# Altium schematic sheet 51MCUBoard.SchDoc
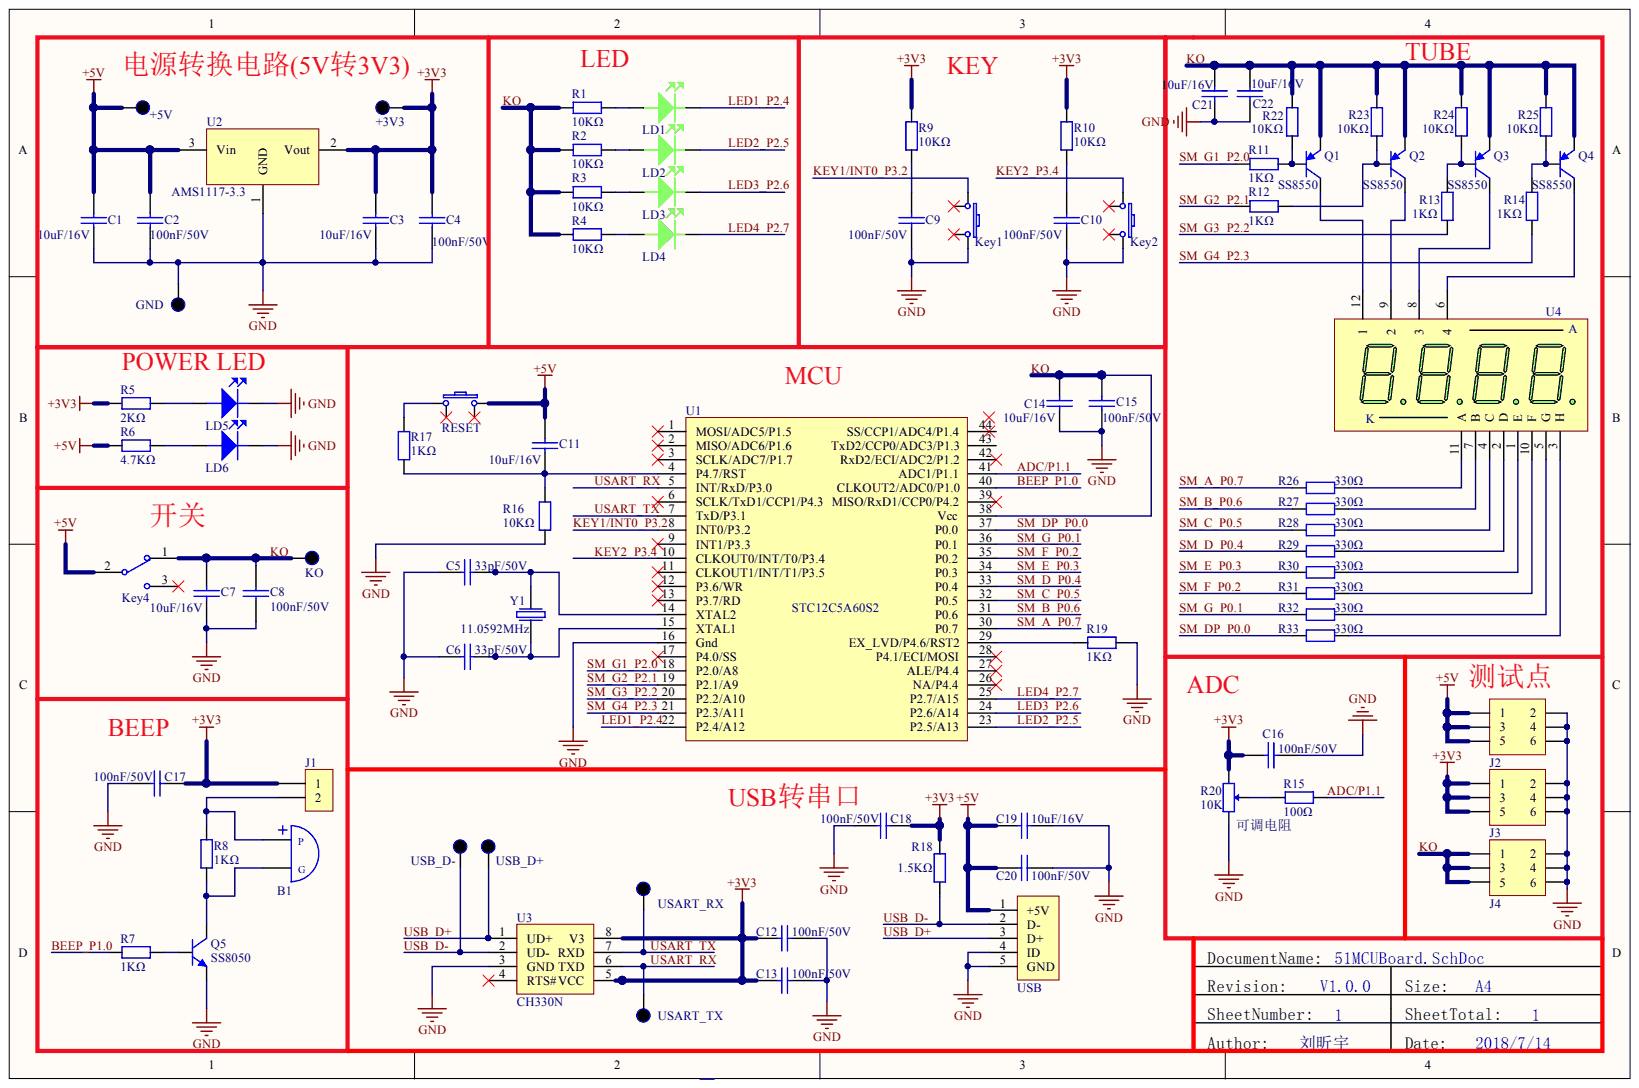
[diagram p0: 51MCUBoard.SchDoc]

## Parts
+3V3  TESTPOINT_0.9  [TESTPOINT_0.9]  (value not drawn)
+5V  TESTPOINT_0.9  [TESTPOINT_0.9]  (value not drawn)
B1  电磁蜂鸣器 有源9*5.5 3V  [BEEP 5x9x5.5]  (value not drawn)
C1  value 10uF/16V  [C 0603]  (hidden comment: 10uF (106) ±10% 16V SMD0603)
C2  value 100nF/50V  [C 0603]  (hidden comment: 100nF (104) ±10% 50V SMD0603)
C3  value 10uF/16V  [C 0603]  (hidden comment: 10uF (106) ±10% 16V SMD0603)
C4  value 100nF/50V  [C 0603]  (hidden comment: 100nF (104) ±10% 50V SMD0603)
C5  value 33pF/50V  [C 0603]  (hidden comment: 33pF (330) ±5% 50V SMD0603)
C6  value 33pF/50V  [C 0603]  (hidden comment: 33pF (330) ±5% 50V SMD0603)
C7  value 10uF/16V  [C 0603]  (hidden comment: 10uF (106) ±10% 16V SMD0603)
C8  value 100nF/50V  [C 0603]  (hidden comment: 100nF (104) ±10% 50V SMD0603)
C9  value 100nF/50V  [C 0603]  (hidden comment: 100nF (104) ±10% 50V SMD0603)
C10  value 100nF/50V  [C 0603]  (hidden comment: 100nF (104) ±10% 50V SMD0603)
C11  value 10uF/16V  [C 0603]  (hidden comment: 10uF (106) ±10% 16V SMD0603)
C12  value 100nF/50V  [C 0603]  (hidden comment: 100nF (104) ±10% 50V SMD0603)
C13  value 100nF/50V  [C 0603]  (hidden comment: 100nF (104) ±10% 50V SMD0603)
C14  value 10uF/16V  [C 0603]  (hidden comment: 10uF (106) ±10% 16V SMD0603)
C15  value 100nF/50V  [C 0603]  (hidden comment: 100nF (104) ±10% 50V SMD0603)
C16  value 100nF/50V  [C 0603]  (hidden comment: 100nF (104) ±10% 50V SMD0603)
C17  value 100nF/50V  [C 0603]  (hidden comment: 100nF (104) ±10% 50V SMD0603)
C18  value 100nF/50V  [C 0603]  (hidden comment: 100nF (104) ±10% 50V SMD0603)
C19  value 10uF/16V  [C 0603]  (hidden comment: 10uF (106) ±10% 16V SMD0603)
C20  value 100nF/50V  [C 0603]  (hidden comment: 100nF (104) ±10% 50V SMD0603)
C21  value 10uF/16V  [C 0603]  (hidden comment: 10uF (106) ±10% 16V SMD0603)
C22  value 10uF/16V  [C 0603]  (hidden comment: 10uF (106) ±10% 16V SMD0603)
GND  TESTPOINT_0.9  [TESTPOINT_0.9]  (value not drawn)
J1  排针 单排 2.54mm 2P  [HDR-1x2]  (value not drawn)
J2  排针 双排 2.54mm 2*3P  [HDR-2X3]  (value not drawn)
J3  排针 双排 2.54mm 2*3P  [HDR-2X3]  (value not drawn)
J4  排针 双排 2.54mm 2*3P  [HDR-2X3]  (value not drawn)
Key1  贴片轻触开关 6*6*6mm  [TSW SMD-6*6*6]  (value not drawn)
Key2  贴片轻触开关 6*6*6mm  [TSW SMD-6*6*6]  (value not drawn)
Key4  拨动开关 2档3脚 直角 美国C&K  [SS-12D06-Z]  (value not drawn)
KO  TESTPOINT_0.9  [TESTPOINT_0.9]  (value not drawn)
LD1  翠绿发光二极管  SMD0805  [LED 0805G]  (value not drawn)
LD2  翠绿发光二极管  SMD0805  [LED 0805G]  (value not drawn)
LD3  翠绿发光二极管  SMD0805  [LED 0805G]  (value not drawn)
LD4  翠绿发光二极管  SMD0805  [LED 0805G]  (value not drawn)
LD5  蓝色发光二极管  SMD0805  [LED 0805B]  (value not drawn)
LD6  蓝色发光二极管  SMD0805  [LED 0805B]  (value not drawn)
Q1  SS8550  [SOT23-3L]
Q2  SS8550  [SOT23-3L]
Q3  SS8550  [SOT23-3L]
Q4  SS8550  [SOT23-3L]
Q5  SS8050  [SOT23-3L]
R1  value 10KΩ  [R 0603]  (hidden comment: 10KΩ (1002) ±1% SMD0402)
R2  value 10KΩ  [R 0603]  (hidden comment: 10KΩ (1002) ±1% SMD0402)
R3  value 10KΩ  [R 0603]  (hidden comment: 10KΩ (1002) ±1% SMD0402)
R4  value 10KΩ  [R 0603]  (hidden comment: 10KΩ (1002) ±1% SMD0402)
R5  value 2KΩ  [R 0603]  (hidden comment: 2KΩ (2001) ±1%  SMD0603)
R6  value 4.7KΩ  [R 0603]  (hidden comment: 4.7KΩ (4701) ±1% SMD0603)
R7  value 1KΩ  [R 0603]  (hidden comment: 1KΩ (1001) ±1% SMD0603)
R8  value 1KΩ  [R 0603]  (hidden comment: 1KΩ (1001) ±1% SMD0603)
R9  value 10KΩ  [R 0603]  (hidden comment: 10KΩ (1002) ±1% SMD0402)
R10  value 10KΩ  [R 0603]  (hidden comment: 10KΩ (1002) ±1% SMD0402)
R11  value 1KΩ  [R 0603]  (hidden comment: 1KΩ (1001) ±1% SMD0603)
R12  value 1KΩ  [R 0603]  (hidden comment: 1KΩ (1001) ±1% SMD0603)
R13  value 1KΩ  [R 0603]  (hidden comment: 1KΩ (1001) ±1% SMD0603)
R14  value 1KΩ  [R 0603]  (hidden comment: 1KΩ (1001) ±1% SMD0603)
R15  value 100Ω  [R 0603]  (hidden comment: 100Ω (1000) ±1% SMD0603)
R16  value 10KΩ  [R 0603]  (hidden comment: 10KΩ (1002) ±1% SMD0402)
R17  value 1KΩ  [R 0603]  (hidden comment: 1KΩ (1001) ±1% SMD0603)
R18  value 1.5KΩ  [R 0603]  (hidden comment: 1.5KΩ (1501) ±1%  SMD0603)
R19  value 1KΩ  [R 0603]  (hidden comment: 1KΩ (1001) ±1% SMD0603)
R20  可调电阻  value 10K  [滑动变阻器]
R22  value 10KΩ  [R 0603]  (hidden comment: 10KΩ (1002) ±1% SMD0603)
R23  value 10KΩ  [R 0603]  (hidden comment: 10KΩ (1002) ±1% SMD0603)
R24  value 10KΩ  [R 0603]  (hidden comment: 10KΩ (1002) ±1% SMD0603)
R25  value 10KΩ  [R 0603]  (hidden comment: 10KΩ (1002) ±1% SMD0603)
R26  value 330Ω  [R 0603]  (hidden comment: 330Ω (3300) ±1% SMD0603)
R27  value 330Ω  [R 0603]  (hidden comment: 330Ω (3300) ±1% SMD0603)
R28  value 330Ω  [R 0603]  (hidden comment: 330Ω (3300) ±1% SMD0603)
R29  value 330Ω  [R 0603]  (hidden comment: 330Ω (3300) ±1% SMD0603)
R30  value 330Ω  [R 0603]  (hidden comment: 330Ω (3300) ±1% SMD0603)
R31  value 330Ω  [R 0603]  (hidden comment: 330Ω (3300) ±1% SMD0603)
R32  value 330Ω  [R 0603]  (hidden comment: 330Ω (3300) ±1% SMD0603)
R33  value 330Ω  [R 0603]  (hidden comment: 330Ω (3300) ±1% SMD0603)
RESET  贴片轻触开关 6*6*6mm  [TSW SMD-6*6*6]  (value not drawn)
U1  STC12C5A60S2  [LQFP44 10X10_N]
U2  AMS1117-3.3  [SOT223_N]
U3  CH330N  [LC-SOP-8_150mil]
U4  四位共阳黄绿色数码管  [SMG 0.36-4P]  (value not drawn)
USART_RX  TESTPOINT_0.9  [TESTPOINT_0.9]  (value not drawn)
USART_TX  TESTPOINT_0.9  [TESTPOINT_0.9]  (value not drawn)
USB  MINI 5P母头贴板 铜壳带柱  [USB-MINI-A]  (value not drawn)
USB_D+  TESTPOINT_0.9  [TESTPOINT_0.9]  (value not drawn)
USB_D-  TESTPOINT_0.9  [TESTPOINT_0.9]  (value not drawn)
Y1  value 11.0592MHz  [XTAL-49S SMD]  (hidden comment: 贴片晶振 49SMD 11.0592MHz)

## Nets
+3V3: +3V3.1 C3.1 C4.1 C12.1 C13.1 C16.1 C17.2 C18.2 J1.1 J3.1 J3.3 J3.5 R5.1 R9.1 R10.1 R18.1 R20.1 U2.2 U3.5(VCC) U3.8(V3)
+5V: +5V.1 C1.1 C2.1 C11.1 C19.1 C20.1 J2.1 J2.3 J2.5 Key4.2 R6.1 RESET.3 U2.3 USB.1(+5V)
GND: C1.2 C2.2 C3.2 C4.2 C5.1 C6.1 C7.2 C8.2 C9.2 C10.2 C12.2 C13.2 C14.2 C15.2 C16.2 C17.1 C18.1 C19.2 C20.2 C21.2 C22.1 GND.1 J2.2 J2.4 J2.6 J3.2 J3.4 J3.6 J4.2 J4.4 J4.6 Key1.3 Key2.3 LD5.2 LD6.2 Q5.2 R16.2 R19.2 R20.3 U1.16(Gnd) U2.1 U3.3(GND) USB.4(ID) USB.5(GND)
ADC/P1.1: R15.2 U1.41(ADC1/P1.1)
BEEP_P1.0: R7.2 U1.40(CLKOUT2/ADC0/P1.0)
KEY1/INT0_P3.2: Key1.1 U1.8(INT0/P3.2)
KEY2_P3.4: Key2.1 U1.10(CLKOUT0/INT/T0/P3.4)
KO: C7.1 C8.1 C14.1 C15.1 C21.1 C22.2 J4.1 J4.3 J4.5 Key4.1 KO.1 Q1.2 Q2.2 Q3.2 Q4.2 R1.2 R2.2 R3.2 R4.2 R22.1 R23.1 R24.1 R25.1 U1.38(Vcc)
LED1_P2.4: LD1.2 U1.22(P2.4/A12)
LED2_P2.5: LD2.2 U1.23(P2.5/A13)
LED3_P2.6: LD3.2 U1.24(P2.6/A14)
LED4_P2.7: LD4.2 U1.25(P2.7/A15)
SM_A_P0.7: R26.1 U1.30(P0.7)
SM_B_P0.6: R27.1 U1.31(P0.6)
SM_C_P0.5: R28.1 U1.32(P0.5)
SM_D_P0.4: R29.1 U1.33(P0.4)
SM_DP_P0.0: R33.1 U1.37(P0.0)
SM_E_P0.3: R30.1 U1.34(P0.3)
SM_F_P0.2: R31.1 U1.35(P0.2)
SM_G1_P2.0: R11.2 U1.18(P2.0/A8)
SM_G2_P2.1: R12.2 U1.19(P2.1/A9)
SM_G3_P2.2: R13.2 U1.20(P2.2/A10)
SM_G4_P2.3: R14.2 U1.21(P2.3/A11)
SM_G_P0.1: R32.1 U1.36(P0.1)
USART_RX: U1.5(INT/RxD/P3.0) U3.6(TXD) USART_TX.1
USART_TX: U1.7(TxD/P3.1) U3.7(RXD) USART_RX.1
USB_D+: U3.1(UD+) USB.3(D+) USB_D+.1
USB_D-: R18.2 U3.2(UD-) USB.2(D-) USB_D-.1
NetB1_1: B1.1 J1.2 R8.1
NetB1_2: B1.2 Q5.3 R8.2
NetC5_2: C5.2 U1.14(XTAL2) Y1.1
NetC6_2: C6.2 U1.15(XTAL1) Y1.2
NetC9_1: C9.1 R9.2
NetC10_1: C10.1 R10.2
NetC11_2: C11.2 R16.1 R17.2 U1.4(P4.7/RST)
NetLD1_1: LD1.1 R1.1
NetLD2_1: LD2.1 R2.1
NetLD3_1: LD3.1 R3.1
NetLD4_1: LD4.1 R4.1
NetLD5_1: LD5.1 R5.2
NetLD6_1: LD6.1 R6.2
NetQ1_1: Q1.1 R11.1 R22.2
NetQ1_3: Q1.3 U4.12
NetQ2_1: Q2.1 R12.1 R23.2
NetQ2_3: Q2.3 U4.9
NetQ3_1: Q3.1 R13.1 R24.2
NetQ3_3: Q3.3 U4.8
NetQ4_1: Q4.1 R14.1 R25.2
NetQ4_3: Q4.3 U4.6
NetQ5_1: Q5.1 R7.1
NetR15_1: R15.1 R20.2
NetR17_1: R17.1 RESET.1
NetR19_1: R19.1 U1.29(EX_LVD/P4.6/RST2)
NetR26_2: R26.2 U4.11(A)
NetR27_2: R27.2 U4.7(B)
NetR28_2: R28.2 U4.4(C)
NetR29_2: R29.2 U4.2(D)
NetR30_2: R30.2 U4.1(E)
NetR31_2: R31.2 U4.10(F)
NetR32_2: R32.2 U4.5(G)
NetR33_2: R33.2 U4.3(H)
N00013:

Not connected: Key1 2 4; Key2 2 4; Key4 3; RESET 2 4; U1 1(MOSI/ADC5/P1.5) 2(MISO/ADC6/P1.6) 3(SCLK/ADC7/P1.7) 6(SCLK/TxD1/CCP1/P4.3) 9(INT1/P3.3) 11(CLKOUT1/INT/T1/P3.5) 12(P3.6/WR) 13(P3.7/RD) 17(P4.0/SS) 26(NA/P4.4) 27(ALE/P4.4) 28(P4.1/ECI/MOSI) 39(MISO/RxD1/CCP0/P4.2) 42(RxD2/ECI/ADC2/P1.2) 43(TxD2/CCP0/ADC3/P1.3) 44(SS/CCP1/ADC4/P1.4); U3 4(RTS#)

## Library data of the parts
+3V3 +5V GND KO USART_RX USART_TX USB_D+ USB_D- = TESTPOINT_0.9: TESTPOINT_0.9，测试点0.9mm，不需焊接; 原创: SZLY; 备注: 测试点不需焊接; B.元件名称: 测试点0.9mm; Component Kind: Standard; Library Name: STM32CoreBoard.IntLib
B1 = 电磁蜂鸣器 有源9*5.5 3V: 蜂鸣器，电磁蜂鸣器 有源9*5.5 3V，直插，国产，SUN-09505-3; A.元件编号: C47620; C.元件类别: 蜂鸣器; D.元件型号: SUN-09055-3; E.封装规格: 直插; I.焊盘数量: 2; J.品牌产地: 国产; 单价/元（大批量）: 0.87; 原创: SZ-BMQ(Copyright © 2015-2016 SZ-BMQ. All rights reserved.); 备注: 立创非可贴片元器件; B.元件名称: 电磁蜂鸣器 有源9*5.5 3V; Component Kind: Standard; G.电压: 3V; Library Name: AltiumDesignerLib.IntLib
C1 C3 C7 C11 C14 C19 C21 C22 = 10uF (106) ±10% 16V SMD0603: 贴片电容，10uF/16V，±10% ，SMD0603，FH(风华)，0603X106K160NT; A.元件编号: C70225; C.元件类别: 贴片电容; E.封装规格: 0603; F.阻值(Ω)/容值(uF): 10.0000000000; G.电压: 16.00; I.焊盘数量: 2; J.品牌产地: FH(风华); 单价/元（大批量）: 0.233; 原创: SZ-BMQ(Copyright © 2015-2016 SZ-BMQ. All rights reserved.); 备注: 立创可贴片元器件; B.元件名称: 10uF (106) ±10% 16V; Component Kind: Standard; D.元件型号: 0603X106K160NT; H.精度: ±10%; Library Name: AltiumDesignerLib.IntLib
C2 C4 C8-C10 C12 C13 C15-C18 C20 = 100nF (104) ±10% 50V SMD0603: 贴片电容，100nF/50V，±10%，SMD0603，YAGEO（国巨），CC0603KRX7R9BB104; A.元件编号: C14663; C.元件类别: 贴片电容; E.封装规格: 0603; F.阻值(Ω)/容值(uF): 0.1000000000; G.电压: 50.00; I.焊盘数量: 2; J.品牌产地: YAGEO(国巨); 单价/元（大批量）: 0.0106; 原创: SZ-BMQ(Copyright © 2015-2016 SZ-BMQ. All rights reserved.); 备注: 立创可贴片元器件; B.元件名称: 100nF (104) ±10% 50V; Component Kind: Standard; D.元件型号: CC0603KRX7R9BB104; H.精度: ±10%; Library Name: AltiumDesignerLib.IntLib
C5 C6 = 33pF (330) ±5% 50V SMD0603: 贴片电容，33pF/50V，±5%，SMD0603，SAMSUNG(三星)，CL10C330JB8NNNC; A.元件编号: C1663; C.元件类别: 贴片电容; E.封装规格: 0603; F.阻值(Ω)/容值(uF): 0.0000330000; G.电压: 50.00; I.焊盘数量: 2; 单价/元（大批量）: 0.0113; 原创: SZ-BMQ(Copyright © 2015-2016 SZ-BMQ. All rights reserved.); 备注: 立创可贴片元器件; B.元件名称: 33pF (330) ±5%; Component Kind: Standard; D.元件型号: CL10C330JB8NNNC; H.精度: ±5%; J.品牌产地: SAMSUNG(三星); Library Name: AltiumDesignerLib.IntLib
J1 = 排针 单排 2.54mm 2P: 排针，单排，2.54mm，2P，国产; B.元件名称: 2.54mm 1*2P 直 排针; I.焊盘数量: 2; 单价/元（大批量）: 0.31; 原创: SZ-BMQ(Copyright © 2015-2016 SZ-BMQ. All rights reserved.); 备注: 立创非可贴片元器件，C2337，2.54mm 1*40P 直 排针，后加工; C.元件类别: 排针; Component Kind: Standard; E.封装规格: HDR-1X2; Library Name: AltiumDesignerLib.IntLib
J2-J4 = 排针 双排 2.54mm 2*3P: 排母，双排，2.54mm，2*3P，国产; B.元件名称: 2.54mm 2*3P 直 排针; C.元件类别: 排母; I.焊盘数量: 6; 原创: SZ-BMQ(Copyright © 2015-2016 SZ-BMQ. All rights reserved.); 备注: 立创非可贴片元器件，C50980，后加工; Component Kind: Standard; E.封装规格: HDR-2X3; Library Name: AltiumDesignerLib.SCHLIB
Key1 Key2 RESET = 贴片轻触开关 6*6*6mm: 贴片轻触开，6*6*6mm，SMD/6*6*6; A.元件编号: C23873; C.元件类别: 轻触开关; F.阻值(Ω)/容值(uF): 0.0000000000; I.焊盘数量: 4; J.品牌产地: 国产; 单价/元（大批量）: 0.077; 原创: SZ-BMQ(Copyright © 2015-2016 SZ-BMQ. All rights reserved.); 备注: 立创非可贴片元器件; B.元件名称: 贴片轻触开关 6*6*6mm; Component Kind: Standard; D.元件型号: 贴片轻触开关 6*6*6mm; E.封装规格: SMD/6*6*6; Library Name: AltiumDesignerLib.IntLib
Key4 = 拨动开关 2档3脚 直角 美国C&K: 拨动开关，2档3脚，直角，美国C&K，1101M2S3CBE2; I.焊盘数量: 2; 原创: SZ-BMQ(Copyright © 2015-2016 SZ-BMQ. All rights reserved.); 备注: 非立创元器件; B.元件名称: 拨动开关 2档3脚 直角 美国C&K; C.元件类别: 拨动开关; Component Kind: Standard; D.元件型号: 1101M2S3CBE2; J.品牌产地: 美国C&K; Library Name: AltiumDesignerLib.IntLib; 单价/元（大批量）: 2
LD1-LD4 = 翠绿发光二极管  SMD0805: 翠绿发光二极管 ，SMD0805，国产，0805G (翠绿); A.元件编号: C2297; C.元件类别: 发光二极管; E.封装规格: 0805; F.阻值(Ω)/容值(uF): green; I.焊盘数量: 2; J.品牌产地: 国产; 单价/元（大批量）: 0.052; 原创: SZ-BMQ(Copyright © 2015-2016 SZ-BMQ. All rights reserved.); 备注: 立创可贴片元器件; B.元件名称: 翠绿; Component Kind: Standard; D.元件型号: 0805G (翠绿); Library Name: AltiumDesignerLib.IntLib
LD5 LD6 = 蓝色发光二极管  SMD0805: 蓝色发光二极管，SMD0805，国星光电，FC-A2012BK-470H2; A.元件编号: C84259; B.元件名称: 蓝灯 贴片LED (20-55mcd) 编带; C.元件类别: 发光二极管; E.封装规格: 0805; F.阻值(Ω)/容值(uF): blue; I.焊盘数量: 2; J.品牌产地: 国星光电; 单价/元（大批量）: 0.0735; 原创: SZ-BMQ(Copyright © 2015-2016 SZ-BMQ. All rights reserved.); 备注: 立创可贴片元器件; Component Kind: Standard; D.元件型号: FC-A2012BK-470H2; Library Name: AltiumDesignerLib.IntLib
Q1-Q4 = SS8550: 三极管，SS8550 ，PNP，SOT-23-3L，CJ江苏长电，SS8550; A.元件编号: C8542; B.元件名称: SS8550 Y2; C.元件类别: 三极管; D.元件型号: SS8550 Y2 200-350; E.封装规格: SOT-23; I.焊盘数量: 3; J.品牌产地: CJ江苏长电（授权代理）最新产品; 单价/元（大批量）: 0.058; 原创: SZ-BMQ(Copyright © 2015-2016 SZ-BMQ. All rights reserved.); 备注: 立创可贴片元器件; Component Kind: Standard; Library Name: AltiumDesignerLib.IntLib
Q5 = SS8050: 三极管，SS8050，NPN，SOT-23-3L，CJ江苏长电，SS8050; A.元件编号: C2150; B.元件名称: SS8050 Y1; C.元件类别: 三极管; E.封装规格: SOT-23; F.阻值(Ω)/容值(uF): 0.000000000; I.焊盘数量: 3; J.品牌产地: CJ江苏长电（授权代理）最新产品; 单价/元（大批量）: 0.058; 原创: SZ-BMQ(Copyright © 2015-2016 SZ-BMQ. All rights reserved.); 备注: 立创可贴片元器件; Component Kind: Standard; D.元件型号: SS8050; Library Name: AltiumDesignerLib.IntLib
R1-R4 R9 R10 R16 = 10KΩ (1002) ±1% SMD0402: 贴片电阻，10KΩ，±1%，SMD0402，UniOhm台湾厚声（授权代理），0402WGF1002TCE; A.元件编号: C25744; C.元件类别: 贴片电阻; E.封装规格: 0402; F.阻值(Ω)/容值(uF): 10000.0000000000; I.焊盘数量: 2; 单价/元（大批量）: 0.0022; 原创: SZ-BMQ(Copyright @ 2015-2016 SZ-BMQ. All rights reserved.); 备注: 立创可贴片元器件; B.元件名称: 10KΩ (1002) ±1%; Component Kind: Standard; D.元件型号: 0402WGF1002TCE; H.精度: ±1%; J.品牌产地: UniOhm台湾厚声（授权代理）; Library Name: AltiumDesignerLib.IntLib
R5 = 2KΩ (2001) ±1%  SMD0603: 贴片电阻，2KΩ，±1% ，SMD0603，UniOhm台湾厚声（授权代理），0603WAF2001T5E; A.元件编号: C22975; C.元件类别: 贴片电阻; E.封装规格: 0603; F.阻值(Ω)/容值(uF): 2000.0000000000; I.焊盘数量: 2; 单价/元（大批量）: 0.003; 原创: SZ-BMQ(Copyright © 2015-2016 SZ-BMQ. All rights reserved.); 备注: 立创可贴片元器件; B.元件名称: 2KΩ (2001) ±1%; Component Kind: Standard; D.元件型号: 0603WAF2001T5E; H.精度: ±1%; J.品牌产地: UniOhm台湾厚声（授权代理）; Library Name: AltiumDesignerLib.IntLib
R6 = 4.7KΩ (4701) ±1% SMD0603: 贴片电阻，4.7KΩ，±1%，SMD0603，UniOhm台湾厚声（授权代理），0603WAF4701T5E; A.元件编号: C23162; C.元件类别: 贴片电阻; E.封装规格: 0603; F.阻值(Ω)/容值(uF): 4700.0000000000; I.焊盘数量: 2; 单价/元（大批量）: 0.003; 原创: SZ-BMQ(Copyright © 2015-2016 SZ-BMQ. All rights reserved.); 备注: 立创可贴片元器件; B.元件名称: 4.7KΩ (4701) ±1%; Component Kind: Standard; D.元件型号: 0603WAF4701T5E; H.精度: ±1%; J.品牌产地: UniOhm台湾厚声（授权代理）; Library Name: AltiumDesignerLib.IntLib
R7 R8 R11-R14 R17 R19 = 1KΩ (1001) ±1% SMD0603: 贴片电阻，1KΩ，±1%，SMD0603，UniOhm台湾厚声（授权代理），0603F1001T5E; A.元件编号: C21190; C.元件类别: 贴片电阻; E.封装规格: 0603; F.阻值(Ω)/容值(uF): 1000.0000000000; I.焊盘数量: 2; 单价/元（大批量）: 0.003; 原创: SZ-BMQ(Copyright © 2015-2016 SZ-BMQ. All rights reserved.); 备注: 立创可贴片元器件; B.元件名称: 1KΩ (1001) ±1%; Component Kind: Standard; D.元件型号: 0603F1001T5E; H.精度: ±1%; J.品牌产地: UniOhm台湾厚声（授权代理）
  R7 R8 R17 R19: Library Name: AltiumDesignerLib.IntLib
  R11-R14: Library Name: AltiumDesignerLib.SCHLIB
R15 = 100Ω (1000) ±1% SMD0603: 贴片电阻，100Ω， ±1% ，SMD0603，UniOhm台湾厚声（授权代理），0603WAF1000T5E; A.元件编号: C22775; C.元件类别: 贴片电阻; E.封装规格: 0603; F.阻值(Ω)/容值(uF): 100.0000000000; I.焊盘数量: 2; 单价/元（大批量）: 0.0032; 原创: SZ-BMQ(Copyright © 2015-2016 SZ-BMQ. All rights reserved.); 备注: 立创可贴片元器件; B.元件名称: 100Ω (1000) ±1%; Component Kind: Standard; D.元件型号: 0603WAF1000T5E; H.精度: ±1%; J.品牌产地: UniOhm台湾厚声（授权代理）; Library Name: AltiumDesignerLib.IntLib
R18 = 1.5KΩ (1501) ±1%  SMD0603: 贴片电阻，1.5KΩ，±1%，SMD0603，UniOhm台湾厚声（授权代理），0603WAF1501T5E; A.元件编号: C22843; C.元件类别: 贴片电阻; E.封装规格: 0603; F.阻值(Ω)/容值(uF): 1500.0000000000; I.焊盘数量: 2; 单价/元（大批量）: 0.0031; 原创: SZ-BMQ(Copyright © 2015-2016 SZ-BMQ. All rights reserved.); 备注: 立创可贴片元器件; B.元件名称: 1.5KΩ (1501) ±1%; Component Kind: Standard; D.元件型号: 0603WAF1501T5E; H.精度: ±1%; J.品牌产地: UniOhm台湾厚声（授权代理）; Library Name: AltiumDesignerLib.IntLib
R20 = 可调电阻10KΩ 直插: 可调电阻，10KΩ，直插; E.封装规格: 直插; I.焊盘数量: 3; 原创: SZ-BMQ(Copyright © 2015-2016 SZ-BMQ. All rights reserved.); 备注: 非立创元器件; B.元件名称: 可调电阻10KΩ 直插; C.元件类别: 可调电阻; Component Kind: Standard; Library Name: AltiumDesignerLib.IntLib
R22-R25 = 10KΩ (1002) ±1% SMD0603: 贴片电阻，10KΩ，±1%，SMD0603，UniOhm台湾厚声（授权代理），0603WAF1002T5E; A.元件编号: C25804; C.元件类别: 贴片电阻; E.封装规格: 0603; F.阻值(Ω)/容值(uF): 10000.0000000000; I.焊盘数量: 2; 单价/元（大批量）: 0.003; 原创: SZ-BMQ(Copyright © 2015-2016 SZ-BMQ. All rights reserved.); 备注: 立创可贴片元器件; B.元件名称: 10KΩ (1002) ±1%; Component Kind: Standard; D.元件型号: 0603WAF1002T5E; H.精度: ±1%; J.品牌产地: UniOhm台湾厚声（授权代理）; Library Name: AltiumDesignerLib.SCHLIB
R26-R33 = 330Ω (3300) ±1% SMD0603: 贴片电阻，330Ω，±1%，SMD0603，UniOhm台湾厚声（授权代理），0603WAF3300T5E; A.元件编号: C23138; C.元件类别: 贴片电阻; E.封装规格: 0603; F.阻值(Ω)/容值(uF): 330.0000000000; I.焊盘数量: 2; 单价/元（大批量）: 0.0032; 原创: SZ-BMQ(Copyright © 2015-2016 SZ-BMQ. All rights reserved.); 备注: 立创可贴片元器件; B.元件名称: 330Ω (3300) ±1%; Component Kind: Standard; D.元件型号: 0603WAF3300T5E; H.精度: ±1%; J.品牌产地: UniOhm台湾厚声（授权代理）; Library Name: AltiumDesignerLib.SCHLIB
U1 = STC12C5A60S2: 微控制器，STC12C5A60S2-35I-LQFP44 托盘 ，ST(意法半导体)，STC12C5A60S2-35I-LQFP44; A.元件编号: C8696; C.元件类别: ST（意法半导体）; E.封装规格: LQFP-44_10x10x08...; I.焊盘数量: 44; J.品牌产地: STC（宏晶）; 单价/元（大批量）: 8.80; 备注: 立创可贴片元器件; B.元件名称: STC12C5A60S2; Component Kind: Standard; D.元件型号: STC12C5A60S2-35I-LQFP44; Library Name: AltiumDesignerLib.IntLib
U2 = AMS1117-3.3: 低压差线性稳压(LDO)，AMS1117-3.3，SOT-223，美国AMS，AMS1117-3.3; A.元件编号: C6186; I.焊盘数量: 4; J.品牌产地: 美国AMS; 单价/元（大批量）: 0.484; 原创: SZ-BMQ(Copyright © 2015-2016 SZ-BMQ. All rights reserved.); 备注: 立创可贴片元器件; B.元件名称: AMS1117-3.3; C.元件类别: 低压差线性稳压(LDO); Component Kind: Standard; D.元件型号: AMS1117-3.3; E.封装规格: SOT-223; Library Name: AltiumDesignerLib.IntLib
U3 = CH330N SOP-8_150mil: USB，SOP-8_150mil，WCH(南京沁恒) ，CH330N; C.元件类别: USB; I.焊盘数量: 16; 单价/元（大批量）: 1.61; 备注: 立创可贴片元器件; A.元件编号: CH330N; B.元件名称: CH330N; Component Kind: Standard; D.元件型号: CH330N; E.封装规格: SOP-8_150mil; F.阻值(Ω)/容值(uF): CH330N; J.品牌产地: WCH(南京沁恒); Library Name: AltiumDesignerLib.IntLib
U4 = 四位共阳黄绿色数码管: 七段数码管， 四位，共阳，黄绿色，国产，3461BG; C.元件类别: 七段数码管; D.元件型号: 3461BG; I.焊盘数量: 12; 原创: SZ-BMQ(Copyright © 2015-2016 SZ-BMQ. All rights reserved.); 备注: 非立创元器件; B.元件名称: 四位共阳黄绿色数码管; Component Kind: Standard; Library Name: AltiumDesignerLib.IntLib
USB = MINI 5P母头贴板 铜壳带柱: MINI 5P，母头贴板，铜壳带柱，LCP黑胶，国产; A.元件编号: C69074; B.元件名称: MINI 5P母头贴板 铜壳带柱 LCP黑胶; C.元件类别: USB连接器; I.焊盘数量: 9; J.品牌产地: LC（立创）; 单价/元（大批量）: 0.0228; 原创: SZ-BMQ(Copyright © 2015-2016 SZ-BMQ. All rights reserved.); 备注: 立创非可贴片元器件; Component Kind: Standard; Library Name: AltiumDesignerLib.IntLib
Y1 = 贴片晶振 49SMD 11.0592MHz: 贴片晶振，49SMD，11.0592MHz，HC-49SMD，YXC，X49SM110592MSD2SC; A.元件编号: C2245; B.元件名称: HC-49SMD 11.0592MHZ 20PF 20PPM; I.焊盘数量: 2; J.品牌产地: YXC; 单价/元（大批量）: 0.356; 原创: SZ-BMQ(Copyright © 2015-2016 SZ-BMQ. All rights reserved.); 备注: 立创非可贴片元器件; C.元件类别: 49SMD; Component Kind: Standard; D.元件型号: X49SM110592MSD2SC; E.封装规格: HC-49SMD; Library Name: AltiumDesignerLib.IntLib
